# Altium schematic sheet 103RCT6.SchDoc
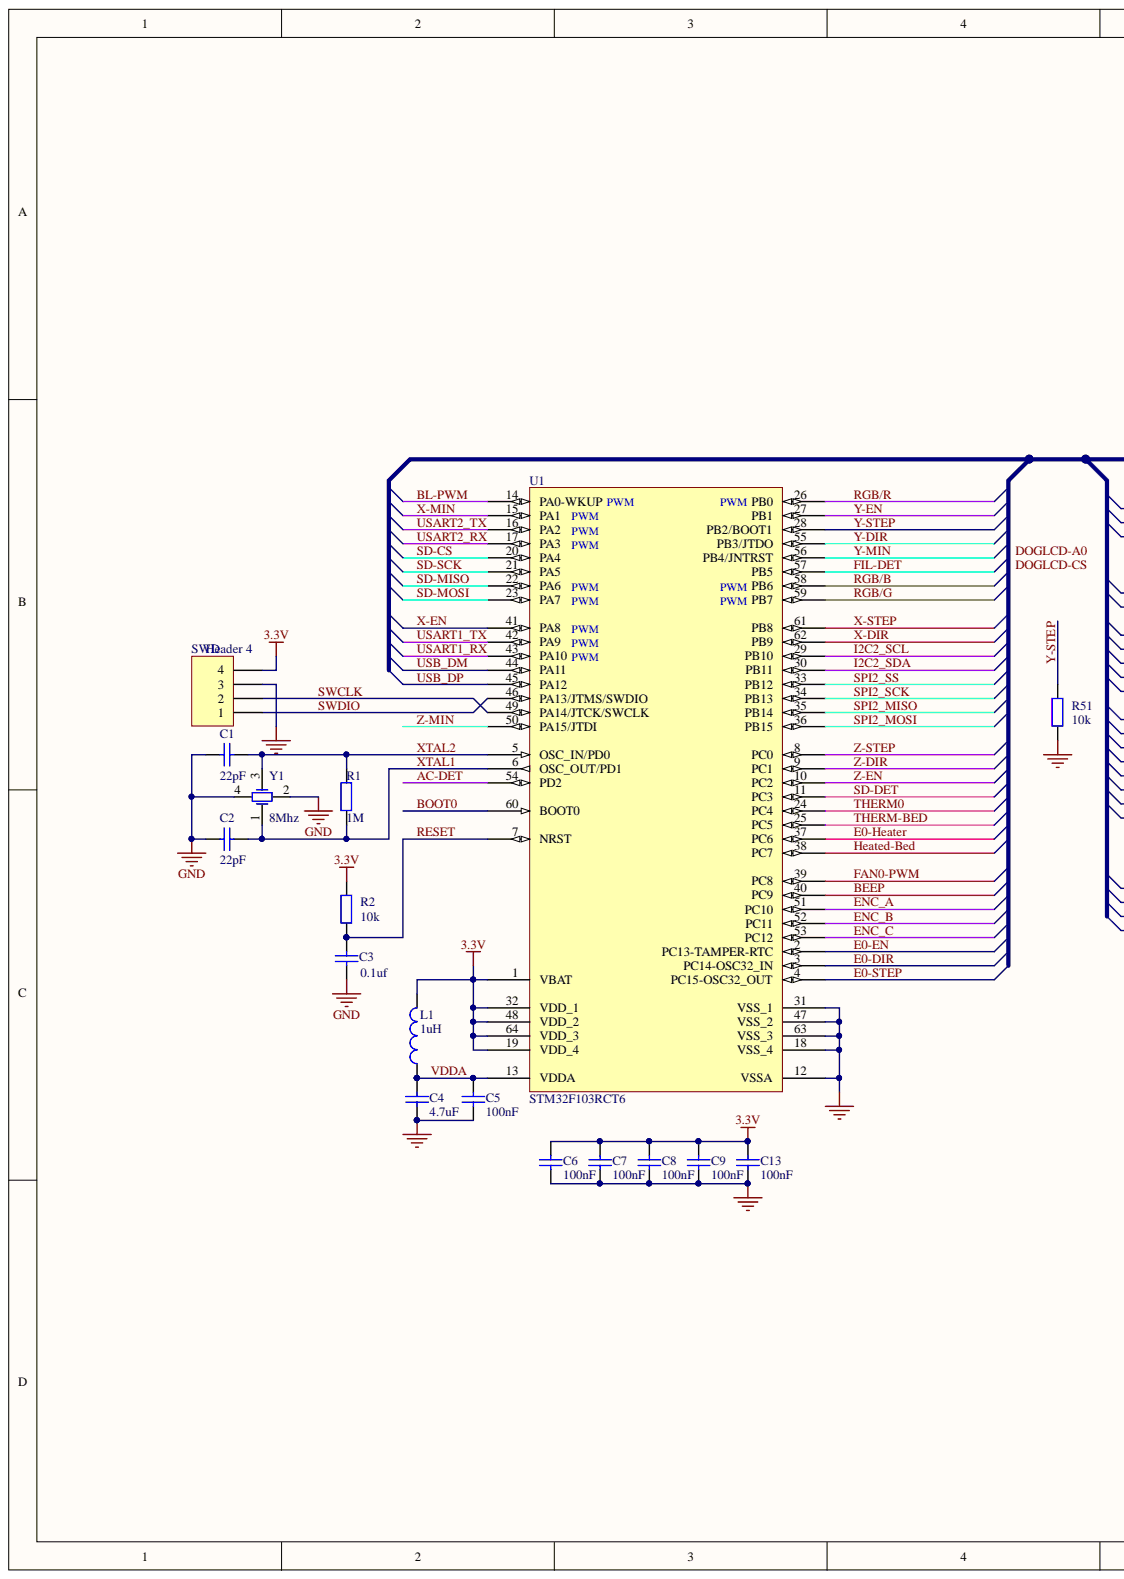
[diagram p0: 103RCT6.SchDoc, left]
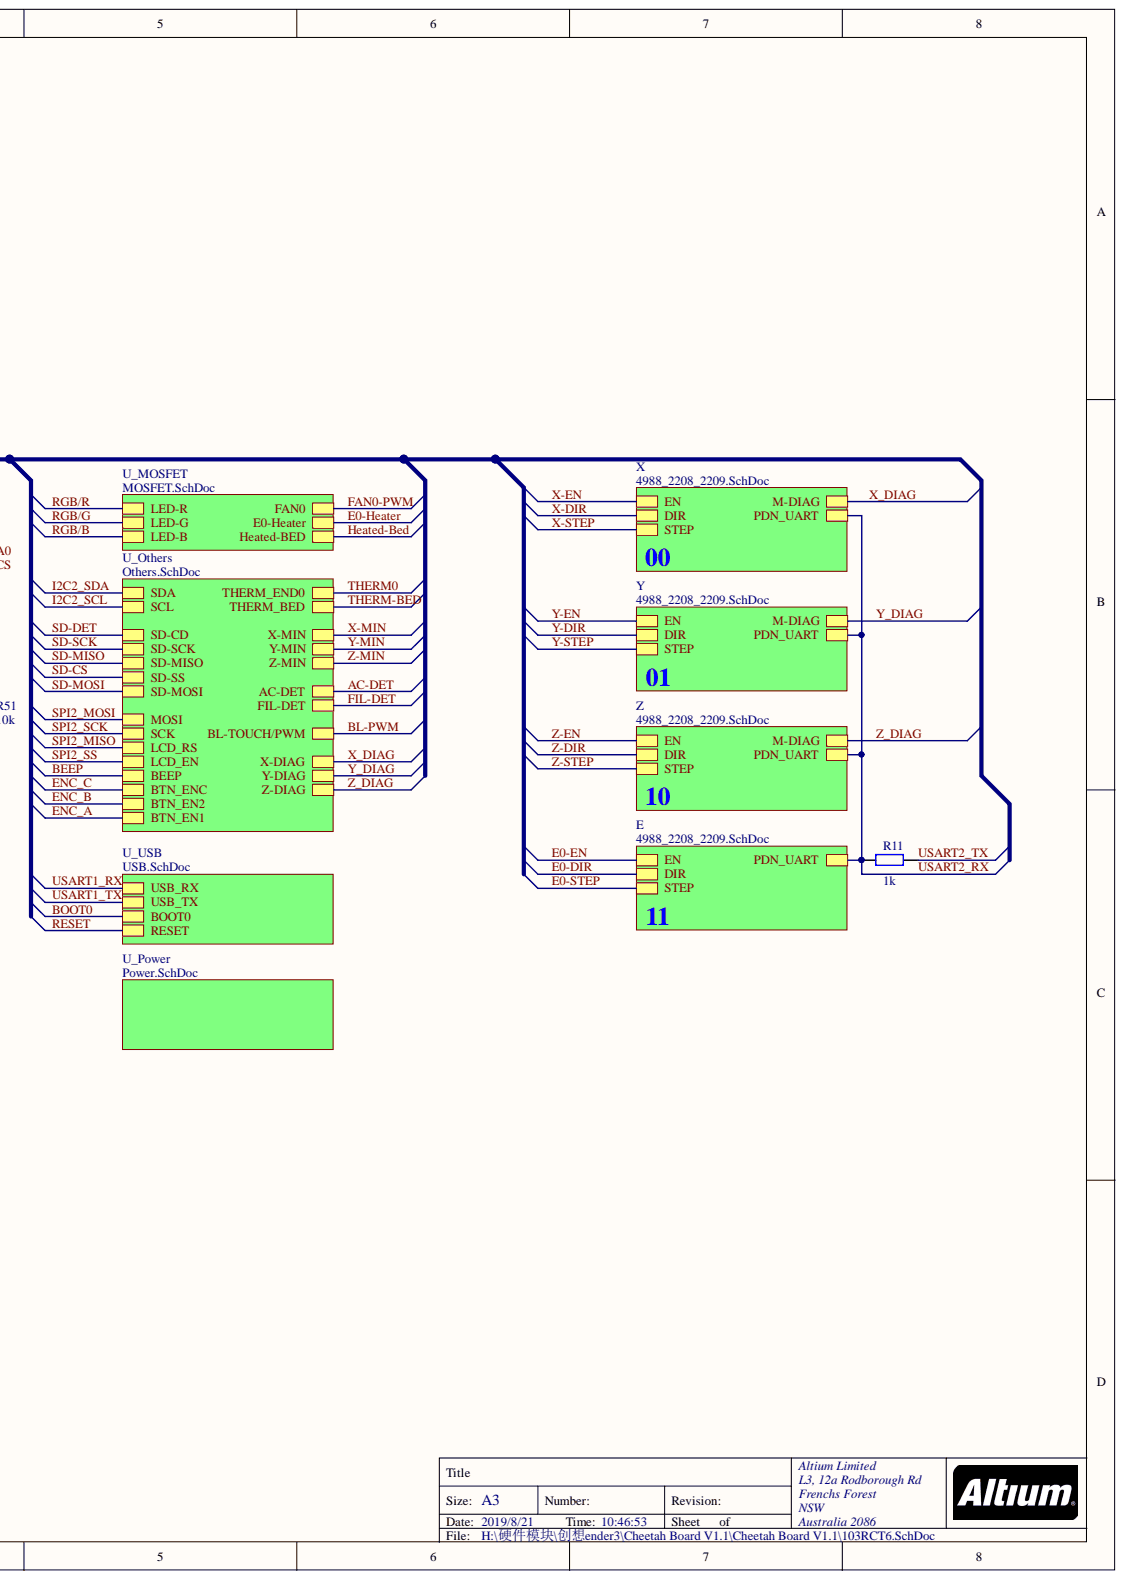
[diagram p1: 103RCT6.SchDoc, right]

## Parts
C1  22pF  [CAP0402]
C2  22pF  [CAP0402]
C3  0.1uF  [CAP0402]
C4  4.7uF  [CAP0402]
C5  100nF  [CAP0402]
C6  100nF  [CAP0402]
C7  100nF  [CAP0402]
C8  100nF  [CAP0402]
C9  100nF  [CAP0402]
C13  100nF  [CAP0402]
L1  1uH  [RES0603]
R1  1M  [RES0402]
R2  10K  [RES0402]
R11  1K  [RES0402]
R51  10K  [RES0402]
SWD  Header 4  [Header 1X4]
U1  STM32F103RCT6  [TQFP64_N]
Y1  8Mhz  [ABM8G_3.2x2.5mm]

## Nets
3.3V: C6.1 C7.1 C8.1 C9.1 C13.1 L1.2 R2.2 SWD.4 U1.1(VBAT) U1.19(VDD_4) U1.32(VDD_1) U1.48(VDD_2) U1.64(VDD_3)
GND: C1.1 C2.1 C3.1 C4.2 C5.2 C6.2 C7.2 C8.2 C9.2 C13.2 R51.1 SWD.3 U1.12(VSSA) U1.18(VSS_4) U1.31(VSS_1) U1.47(VSS_2) U1.63(VSS_3) Y1.2 Y1.4
AC-DET: U1.54(PD2)
BEEP: U1.40(PC9)
BL-PWM: U1.14(PA0-WKUP)
BOOT0: U1.60(BOOT0)
E0-DIR: U1.3(PC14-OSC32_IN)
E0-EN: U1.2(PC13-TAMPER-RTC)
E0-Heater: U1.37(PC6)
E0-STEP: U1.4(PC15-OSC32_OUT)
ENC_A: U1.51(PC10)
ENC_B: U1.52(PC11)
ENC_C: U1.53(PC12)
FAN0-PWM: U1.39(PC8)
FIL-DET: U1.57(PB5)
Heated-Bed: U1.38(PC7)
I2C2_SCL: U1.29(PB10)
I2C2_SDA: U1.30(PB11)
RESET: C3.2 R2.1 U1.7(NRST)
RGB/B: U1.58(PWM_PB6)
RGB/G: U1.59(PWM_PB7)
RGB/R: U1.26(PWM_PB0)
SD-CS: U1.20(PA4)
SD-DET: U1.11(PC3)
SD-MISO: U1.22(PA6)
SD-MOSI: U1.23(PA7)
SD-SCK: U1.21(PA5)
SPI2_MISO: U1.35(PB14)
SPI2_MOSI: U1.36(PB15)
SPI2_SCK: U1.34(PB13)
SPI2_SS: U1.33(PB12)
SWCLK: SWD.2 U1.49(PA14/JTCK/SWCLK)
SWDIO: SWD.1 U1.46(PA13/JTMS/SWDIO)
THERM0: U1.24(PC4)
THERM-BED: U1.25(PC5)
USART1_RX: U1.43(PA10)
USART1_TX: U1.42(PA9)
USART2_RX: R11.2 U1.17(PA3)
USART2_TX: R11.1 U1.16(PA2)
USB_DM: U1.44(PA11)
USB_DP: U1.45(PA12)
VDDA: C4.1 C5.1 L1.1 U1.13(VDDA)
X-DIR: U1.62(PB9)
X-EN: U1.41(PA8)
X-MIN: U1.15(PA1)
X-STEP: U1.61(PB8)
XTAL1: C2.2 R1.1 U1.6(OSC_OUT/PD1) Y1.1
XTAL2: C1.2 R1.2 U1.5(OSC_IN/PD0) Y1.3
Y-DIR: U1.55(PB3/JTDO)
Y-EN: U1.27(PB1)
Y-MIN: U1.56(PB4/JNTRST)
Y-STEP: R51.2 U1.28(PB2/BOOT1)
Z-DIR: U1.9(PC1)
Z-EN: U1.10(PC2)
Z-MIN: U1.50(PA15/JTDI)
Z-STEP: U1.8(PC0)
DOGLCD-A0:
DOGLCD-CS:
N00062:
X_DIAG:
Y_DIAG:
Z_DIAG:

## Library data of the parts
C1-C3 = Cap: Capacitor; Component Kind: Standard; LatestRevisionDate: 17-Jul-2002; LatestRevisionNote: Re-released for DXP Platform.; Library Name: Miscellaneous Devices.IntLib; PackageReference: RAD-0.3; Published: 8-Jun-2000; Publisher: Altium Limited
  C1 C2: PackageDescription: Radial Cap, Thru-Hole; 2 Leads; 0.3 in Pin Spacing; Signal Integrity: Cap; Simulation: CAP; Value: 22pF
  C3: Value: 0.1uf
C4 = Capacitor_1: RO X5R 10UF 10% 35V 1206; Faber ItemCode: 35436800; ID: Library_CAP-0005; Component Kind: Standard; Value: 4.7uF; Library Name: Ultimaker.IntLib
C5-C9 C13 = Capacitor: RO X7R 100nF 10% 50V 0603; Faber ItemCode: 45068500; ID: Library_CAP-0002; Component Kind: Standard; Value: 100nF; Library Name: Ultimaker.SCHLIB
L1 = Inductor: Value: 100uH; Component Kind: Standard; Description: Inductor; Library Name: Miscellaneous Devices.IntLib; Published: 8-Jun-2000; Revision: July-2002: Re-released for DXP Platform.; Publisher: Altium Limited
R1 R2 R11 R51 = Res2: Resistor; Component Kind: Standard; LatestRevisionDate: 17-Jul-2002; LatestRevisionNote: Re-released for DXP Platform.; Library Name: Miscellaneous Devices.IntLib; PackageReference: AXIAL-0.4; Published: 8-Jun-2000; Publisher: Altium Limited
  R1: PackageDescription: Axial Device, Thru-Hole; 2 Leads; 0.4 in Pin Spacing; Signal Integrity: Res; Simulation: RESISTOR; Value: 1M
  R2 R51: Value: 10k
  R11: Value: 1k
SWD = Header 4: Header, 4-Pin; Component Kind: Standard; LatestRevisionDate: 17-Jul-2002; LatestRevisionNote: Re-released for DXP Platform.; Library Name: Miscellaneous Connectors.IntLib; Signal Integrity: Connector; Publisher: Altium Limited
U1 = STM32F103RBT6: STM32 ARM-based 32-bit MCU with 128 Kbytes Flash, 64-pin LQFP, Industrial Temperature; Component Kind: Standard; ComponentLink1Description: Manufacturer Link; ComponentLink1URL: www.st.com/stm32; ComponentLink2Description: Datasheet; ComponentLink2URL: http://www.st.com/stonline/products/literature/ds/13587.pdf; DatasheetVersion: rev6, Mar-2008; Library Name: ST Microcontroller 32-Bit STM32.IntLib; PackageDescription: 64-Pin Low-Profile Quad Flat Pack, 10 x 10 mm Body, 0.5 mm Pitch (LQFP64); PackageReference: LQFP64; PackageVersion: Mar-2008; Published: 30-May-2008; Publisher: Altium Limited
Y1 = Crystal_4: Component Kind: Standard; Library Name: 接插件库.SCHLIB
